# Altium schematic sheet FPGAboard.SchDoc(FPGAboard) (continued)

## Library data of the parts
C6-C8 C10-C19 C26 C27 C30-C43 C46-C48 = C0805C104K5RACTU: Manufacturer Part Number: C0805C104K5RAC-TU; Manufacturer: Kemet; Voltage, Rating: 50 VDC; Tolerance: -10, +10 %; Material, Element: Ceramic; Dielectric Characteristic: X7R; Brand/Series: C Series; Termination: SMD; Supplier Part Number 1: 399-1170-1-ND; Supplier 1: Digikey; Stock 1: 2895664; Pricing 1: 1=0.1, 10=0.053, 25=0.0388, 50=0.029, 100=0.0237, 250=0.0198, 500=0.0169, 1000=0.0133 (USD); Packaging: Cut Tape; Mounting Type: PCB; Dimensions: 0.079" x 0.049" (2 x 1.25mm) LxW; ComponentLink5URL: https://datasheet.ciiva.com/18410/70095383-18410815.pdf; ComponentLink5Description: https://datasheet.ciiva.com/18410/70095383-18410815.pdf; ComponentLink4URL: https://datasheet.ciiva.com/32431/slceramic-chip-condensers-e-32431755.pdf; ComponentLink4Description: https://datasheet.ciiva.com/32431/slceramic-chip-condensers-e-32431755.pdf; ComponentLink3URL: https://datasheet.ciiva.com/7842/1851736-7842539.pdf; ComponentLink3Description: https://datasheet.ciiva.com/7842/1851736-7842539.pdf; ComponentLink2URL: https://datasheet.ciiva.com/28682/1851736-28682232.pdf; ComponentLink2Description: https://datasheet.ciiva.com/28682/1851736-28682232.pdf; ComponentLink1URL: https://datasheet.ciiva.com/14589/70095383-14589048.pdf; ComponentLink1Description: https://datasheet.ciiva.com/14589/70095383-14589048.pdf; Component Kind: Standard; Case Size: 0805 (2012 mm); Library Name: FPGAboard.SchLib
C9 = C0805C104K5RACTU: Manufacturer Part Number: C0805C104K5RAC-TU; Manufacturer: Kemet; Voltage, Rating: 50 VDC; Tolerance: -10, +10 %; Material, Element: Ceramic; Dielectric Characteristic: X7R; Capacitance: 0.1 μF; Brand/Series: C Series; Termination: SMD; Supplier Part Number 1: 399-1170-1-ND; Supplier 1: Digikey; Stock 1: 2895664; Pricing 1: 1=0.1, 10=0.053, 25=0.0388, 50=0.029, 100=0.0237, 250=0.0198, 500=0.0169, 1000=0.0133 (USD); Packaging: Cut Tape; Mounting Type: PCB; Dimensions: 0.079" x 0.049" (2 x 1.25mm) LxW; ComponentLink5URL: https://datasheet.ciiva.com/18410/70095383-18410815.pdf; ComponentLink5Description: https://datasheet.ciiva.com/18410/70095383-18410815.pdf; ComponentLink4URL: https://datasheet.ciiva.com/32431/slceramic-chip-condensers-e-32431755.pdf; ComponentLink4Description: https://datasheet.ciiva.com/32431/slceramic-chip-condensers-e-32431755.pdf; ComponentLink3URL: https://datasheet.ciiva.com/7842/1851736-7842539.pdf; ComponentLink3Description: https://datasheet.ciiva.com/7842/1851736-7842539.pdf; ComponentLink2URL: https://datasheet.ciiva.com/28682/1851736-28682232.pdf; ComponentLink2Description: https://datasheet.ciiva.com/28682/1851736-28682232.pdf; ComponentLink1URL: https://datasheet.ciiva.com/14589/70095383-14589048.pdf; ComponentLink1Description: https://datasheet.ciiva.com/14589/70095383-14589048.pdf; Component Kind: Standard; Case Size: 0805 (2012 mm); Library Name: FPGAboard.SchLib
C20 C23 = C0805C474K5RACTU: Part # Aliases: C0805C474K5RAC7800; Manufacturer: KEMET; Voltage Rating DC: 50 V; Type: SMD MLCC X7R 6.3 - 250 VDC Commerical Grade; Tolerance: 10 %; Temperature Coefficient / Code: +/- 15 %; Dielectric: X7R; Class: Class 1; Case Width: 1.2 mm; Case Length: 2 mm; Case Height: 1 mm; Capacitance - pF: 470000 pF; Capacitance: 0.47 uF; Voltage Rating: 50 V; Termination Style: SMD/SMT; Supplier Part Number 1: 399-8100-1-ND; Supplier 1: Digikey; Stock 1: 94831; Series: C0805C; RoHS: Details; Product Category: Multilayer Ceramic Capacitors MLCC - SMD/SMT; Product: General Type MLCCs; Pricing 1: 1=0.28, 10=0.193, 100=0.1092, 500=0.0771, 1000=0.0675 (USD); Packaging: Reel; Package / Case: 0805 (2012 metric); Operating Temperature Range: - 55 C to + 125 C; Minimum Operating Temperature: - 55 C; Maximum Operating Temperature: + 125 C; Manufacturer Part Number: C0805C474K5RACTU; Factory Pack Quantity: 2500; Dimensions: 1.2 mm W x 2 mm L x 1 mm H; ComponentLink7URL: https://datasheet.ciiva.com/14589/70095383-14589439.pdf; ComponentLink7Description: https://datasheet.ciiva.com/14589/70095383-14589439.pdf; ComponentLink6URL: https://datasheet.ciiva.com/14604/70095383-14604428.pdf; ComponentLink6Description: https://datasheet.ciiva.com/14604/70095383-14604428.pdf; ComponentLink5URL: https://datasheet.ciiva.com/5411/kem-c1002-x7r-smd-5411451.pdf; ComponentLink5Description: https://datasheet.ciiva.com/5411/kem-c1002-x7r-smd-5411451.pdf; ComponentLink4URL: https://datasheet.ciiva.com/28614/1918693-28614762.pdf; ComponentLink4Description: https://datasheet.ciiva.com/28614/1918693-28614762.pdf; ComponentLink3URL: https://datasheet.ciiva.com/6126/kem-c1002-x7r-smd-6126343.pdf; ComponentLink3Description: https://datasheet.ciiva.com/6126/kem-c1002-x7r-smd-6126343.pdf; ComponentLink2URL: https://datasheet.ciiva.com/28688/1851736-28688678.pdf; ComponentLink2Description: https://datasheet.ciiva.com/28688/1851736-28688678.pdf; ComponentLink1URL: https://datasheet.ciiva.com/7842/1851736-7842613.pdf; ComponentLink1Description: https://datasheet.ciiva.com/7842/1851736-7842613.pdf; Component Kind: Standard; Case Code - mm: 2012; Case Code - in: 0805; Brand: Kemet; Library Name: FPGAboard.SchLib
C21 C22 = C0805C120J5GACTU: Part # Aliases: C0805C120J5GAC7800; Manufacturer: KEMET; Voltage Rating DC: 50 V; Type: SMD MLCC C0G 10 - 250 VDC Commercial Grade; Tolerance: 5 %; Temperature Coefficient / Code: +/- 30 PPM / C; Dissipation Factor DF: 0.1; Dielectric: C0G (NP0); Class: Class 1; Case Width: 1.2 mm; Case Length: 2 mm; Case Height: 0.78 mm; Voltage Rating: 50 V; Termination Style: SMD/SMT; Supplier Part Number 1: 399-1110-1-ND; Supplier 1: Digikey; Stock 1: 56795; Series: C0805C; RoHS: Details; Product Category: Multilayer Ceramic Capacitors MLCC - SMD/SMT; Product: General Type MLCCs; Pricing 1: 1=0.12, 10=0.085, 100=0.0402, 500=0.0282, 1000=0.0233 (USD); Packaging: Reel; Package / Case: 0805 (2012 metric); Operating Temperature Range: - 55 C to + 125 C; Minimum Operating Temperature: - 55 C; Maximum Operating Temperature: + 125 C; Manufacturer Part Number: C0805C120J5GACTU; Lead Spacing: 0.75 mm; Factory Pack Quantity: 4000; Dimensions: 1.2 mm W x 2 mm L x 0.78 mm H; ComponentLink9URL: https://datasheet.ciiva.com/28696/1789732-28696013.pdf; ComponentLink9Description: https://datasheet.ciiva.com/28696/1789732-28696013.pdf; ComponentLink8URL: https://datasheet.ciiva.com/14586/70095383-14586716.pdf; ComponentLink8Description: https://datasheet.ciiva.com/14586/70095383-14586716.pdf; ComponentLink7URL: https://datasheet.ciiva.com/7842/1732692-7842204.pdf; ComponentLink7Description: https://datasheet.ciiva.com/7842/1732692-7842204.pdf; ComponentLink6URL: https://datasheet.ciiva.com/7842/1732692-7842203.pdf; ComponentLink6Description: https://datasheet.ciiva.com/7842/1732692-7842203.pdf; ComponentLink5URL: https://datasheet.ciiva.com/6136/1732692-6136713.pdf; ComponentLink5Description: https://datasheet.ciiva.com/6136/1732692-6136713.pdf; ComponentLink4URL: https://datasheet.ciiva.com/5418/kem-c1003-c0g-smd-356956-5418089.pdf; ComponentLink4Description: https://datasheet.ciiva.com/5418/kem-c1003-c0g-smd-356956-5418089.pdf; ComponentLink3URL: https://datasheet.ciiva.com/18193/getdatasheetpartid-179336-18193526.pdf; ComponentLink3Description: https://datasheet.ciiva.com/18193/getdatasheetpartid-179336-18193526.pdf; ComponentLink2URL: https://datasheet.ciiva.com/11463/kem-c1003-c0g-smd-11463493.pdf; ComponentLink2Description: https://datasheet.ciiva.com/11463/kem-c1003-c0g-smd-11463493.pdf; ComponentLink1URL: https://datasheet.ciiva.com/144/docfinderpartnumber-c0805c120j5gactu-144980.pdf; ComponentLink1Description: https://datasheet.ciiva.com/144/docfinderpartnumber-c0805c120j5gactu-144980.pdf; Component Kind: Standard; Case Code - mm: 2012; Case Code - in: 0805; Brand: Kemet; Library Name: FPGAboard.SchLib
C24 C25 C45 = CMP-1036-04876-1: GRM21BR60J476ME15L; Value: 4.7uF; Tolerance: Â±10%; Case-Metric: 2012; Case-EIA: 0805; Technology: SMT; Rated Voltage: 6.3 V; Max Thickness: 1.45 mm; Library Name: Altium Content Vault; Component Kind: Standard
C28 C29 = CMP-1038-02286-1: C1210C226M3PACTU; Tolerance: -20% to +80%; Case-Metric: 3225; Case-EIA: 1210; Technology: SMT; Rated Voltage: 25 V; Max Thickness: 2.2 mm; Library Name: Altium Content Vault; Component Kind: Standard
C44 C49 = CMP-1035-01192-1: GRM188C81E475KE11D; Value: 75pF; Tolerance: Â±10%; Case-Metric: 1608; Case-EIA: 0603; Technology: SMT; Rated Voltage: 25 V; Max Thickness: 1 mm; Library Name: Altium Content Vault; Component Kind: Standard
CLKOUT Done FLAGA Prog TP1 = TP: Test point; Component Kind: Standard; Library Name: FPGAboard.SchLib
CON1 = T1M-06-F-SH-L-K: 6 Positions Header Connector Surface Mount, Right Angle; Manufacturer: Samtec; Supplier Part Number 1: SAM11991-ND; Supplier 1: Digi-Key; Stock 1: 0; Manufacturer Part Number: T1M-06-F-SH-L-K; Component Kind: Standard; Library Name: FPGAboard.SchLib
CON2 = SAMTEC_LSEM_V_50: SAMTEC connector; Component Kind: Standard; Library Name: FPGAboard.SchLib
CON3 = T1M-10-F-SH-L-K: 10 Positions Header Connector Surface Mount, Right Angle; Component Kind: Standard; Library Name: FPGAboard.SchLib
CON4 = Header 13X2: Header, 13-Pin, Dual row; Signal Integrity: Connector; Library Name: Miscellaneous Connectors.IntLib; LatestRevisionNote: Re-released for DXP Platform.; LatestRevisionDate: 17-Jul-2002; Component Kind: Standard; Publisher: Altium Limited
CON5 = Header 10: Header, 10-Pin; Signal Integrity: Connector; Library Name: Miscellaneous Connectors.IntLib; LatestRevisionNote: Re-released for DXP Platform.; LatestRevisionDate: 17-Jul-2002; Component Kind: Standard; Publisher: Altium Limited
MCX = 0734151061: CONN MCX RCPT STR 50OHM EDGE MNT; Manufacturer: Molex; Voltage Rating: 335V; Shield Termination: Solder; Other Names: 0734-15-1061  073415-1061  0734151061-ND  73415-1061  734151061  WM9351-2-ND  WM9351TR; Online Catalog: MCX, 73366 and 73415 Series; Frequency - Max: 6GHz; Featured Product: MCX RF Connectors; Dielectric Material: Polytetrafluoroethylene (PTFE); Connector Type: Receptacle, Female Socket; Center Contact Plating: Gold; Center Contact Material: Beryllium Copper; Body Material: Brass; Supplier Part Number 1: WM9351CT-ND; Supplier 1: Digi-Key; Stock 1: 18986; Standard Package: 1,000; Series: 73415; RoHS Information: 0734151061 Cert of Compliance; Pricing 1: 1=5.45, 10=5.23, 25=4.7944, 50=4.5764, 100=4.3584, 250=3.8136, 500=3.7047 (USD); Packaging: Tape & Reel (TR); Operating Temperature: -55°C ~ 155°C; Mounting Type: Board Edge, Cutout; Manufacturer Part Number: 0734151061; Ingress Protection: -; Impedance: 50 Ohm; Housing Color: Gold; Features: -; Fastening Type: Snap-On; Family: Coaxial Connectors (RF); Contact Termination: Solder; Connector Style: MCX; ComponentLink2URL: https://datasheet.ciiva.com/7002/0734151061-rf-coax-connectors-7002509.pdf; ComponentLink2Description: https://datasheet.ciiva.com/7002/0734151061-rf-coax-connectors-7002509.pdf; ComponentLink1URL: https://datasheet.ciiva.com/7002/0734151061-rf-coax-connectors-7002503.pdf; ComponentLink1Description: https://datasheet.ciiva.com/7002/0734151061-rf-coax-connectors-7002503.pdf; Component Kind: Standard; Category: Connectors, Interconnects; Cable Group: -; Body Finish: Gold; Library Name: FPGAboard.SchLib
OSC1 = ASVMB-100.000MHZ-XY-T: Manufacturer: Abracon; Standard Frequency: 100 MHz; Rad Hard: No; Load Capacitance: 15 pF; Frequency Stability: ±10 ppm; Type: Silicon Oscillator; Supplier Part Number 1: 535-11246-1-ND; Supplier 1: Digikey; Stock 1: 1752; Pricing 1: 1=2.51, 10=2.449, 50=2.332, 100=2.0405, 500=1.9822 (USD); Packaging: Tape & Reel; Package: 4QFN EP; Manufacturer Part Number: ASVMB-100.000MHZ-XY-T; ComponentLink4URL: https://datasheet.ciiva.com/866/asvmb-866793.pdf; ComponentLink4Description: https://datasheet.ciiva.com/866/asvmb-866793.pdf; ComponentLink3URL: https://datasheet.ciiva.com/866/asvmb-866792.pdf; ComponentLink3Description: https://datasheet.ciiva.com/866/asvmb-866792.pdf; ComponentLink2URL: https://datasheet.ciiva.com/866/asvmb-866791.pdf; ComponentLink2Description: https://datasheet.ciiva.com/866/asvmb-866791.pdf; ComponentLink1URL: https://datasheet.ciiva.com/13167/asvmb-35165-13167142.pdf; ComponentLink1Description: https://datasheet.ciiva.com/13167/asvmb-35165-13167142.pdf; Component Kind: Standard; Category: SMD Crystal Oscillator; Library Name: FPGAboard.SchLib
R6 R9 R10 = CRCW080510K0FKEA_: Manufacturer: Vishay; Voltage Rating: 150 V; Unit Weight: 0.000194 oz; Tolerance: 1 %; Temperature Coefficient: 100 PPM / K; Power Rating: 125 mW (1/8 W); Case Width: 1.25 mm; Case Length: 2 mm; Case Height: 0.45 mm; Brand: Vishay / Dale; Supplier Part Number 1: 541-10.0KCCT-ND; Supplier 1: Digikey; Stock 1: 0; Series: CRCW; RoHS: Details; Product Category: Thick Film Resistors - SMD; Product: Thick Film Resistors SMD; Pricing 1: 1=0.1, 10=0.042, 25=0.0304, 50=0.0232, 100=0.017, 250=0.013, 500=0.0104, 1000=0.0077 (USD); Packaging: Reel; Package / Case: 0805 (2012 metric); Operating Temperature Range: - 55 C to + 155 C; Manufacturer Part Number: CRCW080510K0FKEA; Factory Pack Quantity: 5000; ComponentLink10URL: https://datasheet.ciiva.com/16199/dcrcwe3-16199086.pdf; ComponentLink10Description: https://datasheet.ciiva.com/16199/dcrcwe3-16199086.pdf; ComponentLink9URL: https://datasheet.ciiva.com/8337/dcrcwe3-8337774.pdf; ComponentLink9Description: https://datasheet.ciiva.com/8337/dcrcwe3-8337774.pdf; ComponentLink8URL: https://datasheet.ciiva.com/46394/1720486-46394381.pdf; ComponentLink8Description: https://datasheet.ciiva.com/46394/1720486-46394381.pdf; ComponentLink7URL: https://datasheet.ciiva.com/16755/70201119-16755389.pdf; ComponentLink7Description: https://datasheet.ciiva.com/16755/70201119-16755389.pdf; ComponentLink6URL: https://datasheet.ciiva.com/8337/dcrcwe3-8337773.pdf; ComponentLink6Description: https://datasheet.ciiva.com/8337/dcrcwe3-8337773.pdf; ComponentLink5URL: https://datasheet.ciiva.com/16883/dcrcwe3-16883708.pdf; ComponentLink5Description: https://datasheet.ciiva.com/16883/dcrcwe3-16883708.pdf; ComponentLink4URL: https://datasheet.ciiva.com/16514/dcrcwe3-16514907.pdf; ComponentLink4Description: https://datasheet.ciiva.com/16514/dcrcwe3-16514907.pdf; ComponentLink3URL: https://datasheet.ciiva.com/16719/70201119-16719082.pdf; ComponentLink3Description: https://datasheet.ciiva.com/16719/70201119-16719082.pdf; ComponentLink2URL: https://datasheet.ciiva.com/11808/0900766b80d98b26-11808216.pdf; ComponentLink2Description: https://datasheet.ciiva.com/11808/0900766b80d98b26-11808216.pdf; ComponentLink1URL: https://datasheet.ciiva.com/5547/dcrcwe3-109170-5547559.pdf; ComponentLink1Description: https://datasheet.ciiva.com/5547/dcrcwe3-109170-5547559.pdf; Component Kind: Standard; Case Code - mm: 2012; Case Code - in: 0805; Library Name: FPGAboard.SchLib
R7 R8 = CRCW08052K20FKEA: Manufacturer: Vishay; Voltage Rating: 150 V; Unit Weight: 0.000194 oz; Tolerance: 1 %; Temperature Coefficient: 100 PPM / K; Power Rating: 125 mW (1/8 W); Brand: Vishay / Dale; Width: 1.25 mm; Termination Style: SMD/SMT; Supplier Part Number 1: 541-2.20KCCT-ND; Supplier 1: Digikey; Stock 1: 0; Series: CRCW; RoHS: Details; Product Category: Thick Film Resistors - SMD; Product: Thick Film Resistors SMD; Pricing 1: 1=0.1, 10=0.046, 100=0.0187, 1000=0.0084, 2500=0.0073 (USD); Packaging: Reel; Package / Case: 0805 (2012 metric); Operating Temperature Range: - 55 C to + 155 C; Manufacturer Part Number: CRCW08052K20FKEA; Length: 2 mm; Height: 0.45 mm; Factory Pack Quantity: 5000; ComponentLink12URL: https://datasheet.ciiva.com/3258/dcrcwe3-3258431.pdf; ComponentLink12Description: https://datasheet.ciiva.com/3258/dcrcwe3-3258431.pdf; ComponentLink11URL: https://datasheet.ciiva.com/2525/dcrcwe3-2525871.pdf; ComponentLink11Description: https://datasheet.ciiva.com/2525/dcrcwe3-2525871.pdf; ComponentLink10URL: https://datasheet.ciiva.com/3258/dcrcwe3-3258430.pdf; ComponentLink10Description: https://datasheet.ciiva.com/3258/dcrcwe3-3258430.pdf; ComponentLink9URL: https://datasheet.ciiva.com/2525/dcrcwe3-2525870.pdf; ComponentLink9Description: https://datasheet.ciiva.com/2525/dcrcwe3-2525870.pdf; ComponentLink8URL: https://datasheet.ciiva.com/2525/dcrcwe3-2525869.pdf; ComponentLink8Description: https://datasheet.ciiva.com/2525/dcrcwe3-2525869.pdf; ComponentLink7URL: https://datasheet.ciiva.com/16770/70201119-16770763.pdf; ComponentLink7Description: https://datasheet.ciiva.com/16770/70201119-16770763.pdf; ComponentLink6URL: https://datasheet.ciiva.com/7777/1720486-7777611.pdf; ComponentLink6Description: https://datasheet.ciiva.com/7777/1720486-7777611.pdf; ComponentLink5URL: https://datasheet.ciiva.com/16201/dcrcwe3-16201450.pdf; ComponentLink5Description: https://datasheet.ciiva.com/16201/dcrcwe3-16201450.pdf; ComponentLink4URL: https://datasheet.ciiva.com/7777/1697337-7777385.pdf; ComponentLink4Description: https://datasheet.ciiva.com/7777/1697337-7777385.pdf; ComponentLink3URL: https://datasheet.ciiva.com/17201/dcrcwe3-17201814.pdf; ComponentLink3Description: https://datasheet.ciiva.com/17201/dcrcwe3-17201814.pdf; ComponentLink2URL: https://datasheet.ciiva.com/5546/dcrcwe3-109170-5546726.pdf; ComponentLink2Description: https://datasheet.ciiva.com/5546/dcrcwe3-109170-5546726.pdf; ComponentLink1URL: https://datasheet.ciiva.com/11808/0900766b80d98b26-11808384.pdf; ComponentLink1Description: https://datasheet.ciiva.com/11808/0900766b80d98b26-11808384.pdf; Component Kind: Standard; Case Code - mm: 2012; Case Code - in: 0805; Library Name: FPGAboard.SchLib
R11 = CRCW08050000Z0EA: Manufacturer: Vishay; Tolerance: Jumper; Power (Watts): 0.125W, 1/8W; Other Names: 541-0.0ACT; Online Catalog: CRCW - Automotive Series; Kits: 541-1420-ND  - RESISTOR KIT 0-1M 1/8W 6100PCS; EDA / CAD Models: Download from Accelerated Designs; Temperature Coefficient: -; Supplier Part Number 1: 541-0.0ACT-ND; Supplier Device Package: 0805 (2012 Metric); Supplier 1: Digikey; Stock 1: 1015935; Standard Package: 1; Size / Dimension: 0.079" L x 0.049" W (2.00mm x 1.25mm); Series: CRCW; Pricing 1: 1=0.1, 10=0.026, 25=0.0184, 50=0.014, 100=0.0104, 250=0.0079, 500=0.0063, 1000=0.0047 (USD); Packaging: Cut Tape (CT); Package / Case: 0805 (2012 Metric); Operating Temperature: -55°C ~ 155°C; Number of Terminations: 2; Manufacturer Part Number: CRCW08050000Z0EA; Height: 0.020" (0.50mm); Features: Automotive AEC-Q200; Family: Chip Resistor - Surface Mount; Composition: Thick Film; ComponentLink11URL: https://datasheet.ciiva.com/7841/1720486-7841839.pdf; ComponentLink11Description: https://datasheet.ciiva.com/7841/1720486-7841839.pdf; ComponentLink10URL: https://datasheet.ciiva.com/2463/dcrcwe3-2463039.pdf; ComponentLink10Description: https://datasheet.ciiva.com/2463/dcrcwe3-2463039.pdf; ComponentLink9URL: https://datasheet.ciiva.com/2463/dcrcwe3-2463038.pdf; ComponentLink9Description: https://datasheet.ciiva.com/2463/dcrcwe3-2463038.pdf; ComponentLink8URL: https://datasheet.ciiva.com/7841/1697337-7841629.pdf; ComponentLink8Description: https://datasheet.ciiva.com/7841/1697337-7841629.pdf; ComponentLink7URL: https://datasheet.ciiva.com/2463/dcrcwe3-2463037.pdf; ComponentLink7Description: https://datasheet.ciiva.com/2463/dcrcwe3-2463037.pdf; ComponentLink6URL: https://datasheet.ciiva.com/16719/70201119-16719706.pdf; ComponentLink6Description: https://datasheet.ciiva.com/16719/70201119-16719706.pdf; ComponentLink5URL: https://datasheet.ciiva.com/16389/dcrcwe3-16389338.pdf; ComponentLink5Description: https://datasheet.ciiva.com/16389/dcrcwe3-16389338.pdf; ComponentLink4URL: https://datasheet.ciiva.com/11811/0900766b80d98b26-11811304.pdf; ComponentLink4Description: https://datasheet.ciiva.com/11811/0900766b80d98b26-11811304.pdf; ComponentLink3URL: https://datasheet.ciiva.com/5543/dcrcwe3-109170-5543096.pdf; ComponentLink3Description: https://datasheet.ciiva.com/5543/dcrcwe3-109170-5543096.pdf; ComponentLink2URL: https://datasheet.ciiva.com/26314/fld-crcw-e3-data-en-26314630.pdf; ComponentLink2Description: https://datasheet.ciiva.com/26314/fld-crcw-e3-data-en-26314630.pdf; ComponentLink1URL: https://datasheet.ciiva.com/16883/dcrcwe3-16883622.pdf; ComponentLink1Description: https://datasheet.ciiva.com/16883/dcrcwe3-16883622.pdf; Component Kind: Standard; Category: Resistors; Library Name: FPGAboard.SchLib
R12-R14 R16 = RES 0402: 402 Resistor; Library Name: UNP2.SchLib; Component Kind: Standard
R15 = RES 0603: 603 Resistor; Library Name: UNP2.SchLib; Component Kind: Standard
SW2 = PTS645SM43SMTR92 LFS: SWITCH TACTILE SPST-NO 0.05A 12V; Manufacturer: ITT /  C&K Components; Switch Configuration: N.O.; Pole Throw Configuration: SPST; Operating Force: 1.57 N; Minimum Insulation Resistance: 100000 MOhm; Mechanical Life: 100000 Cycles; Maximum Contact Resistance: 100 mOhm; Illumination Type: N/R; Ground Terminal: No; Dielectric Strength: 250 VAC; Contact Rating @ Voltage: 0.05@12VDC A; Body Orientation: Straight; Actuator Style: Round Button; Actuator Length: 0.85 mm; Type: N/A; Supplier Standard Pack: 1,000; Supplier Part Number 1: CKN9112CT-ND; Supplier 1: C&K Components; Stock 1: 468020; Seal Location: N/A; Seal Description: N/A; RoHS: Yes; Product Weight: N/A; Pricing 1: 1=0.15, 10=0.147, 25=0.1416, 50=0.1366, 100=0.129, 250=0.1214, 500=0.1164 (USD); Part No: PTS645SM43SMTR92LFS; Packaging: Tape and Reel; Operating Temperature: -20 to 60 C; Mounting: Surface Mount,Gull Wing; Maximum DC Voltage Rating: 12 V; Maximum AC Voltage Rating: N/A; Manufacturer Part Number: PTS645SM43SMTR92LFS; Housing Material: N/A; Family: PTS645; Electrical Life: N/A; Dimension: 6 x 9 x 4.3 mm; Contact Plating: Silver; Contact Material: N/A; ComponentLink5URL: https://datasheet.ciiva.com/29931/getdatasheetpartid-764615-29931183.pdf; ComponentLink5Description: https://datasheet.ciiva.com/29931/getdatasheetpartid-764615-29931183.pdf; ComponentLink4URL: https://datasheet.ciiva.com/14920/pts645-14920735.pdf; ComponentLink4Description: https://datasheet.ciiva.com/14920/pts645-14920735.pdf; ComponentLink3URL: https://datasheet.ciiva.com/14920/pts645-14920734.pdf; ComponentLink3Description: https://datasheet.ciiva.com/14920/pts645-14920734.pdf; ComponentLink2URL: https://datasheet.ciiva.com/14920/pts645-14920733.pdf; ComponentLink2Description: https://datasheet.ciiva.com/14920/pts645-14920733.pdf; ComponentLink1URL: https://datasheet.ciiva.com/29903/getdatasheetpartid-786341-29903846.pdf; ComponentLink1Description: https://datasheet.ciiva.com/29903/getdatasheetpartid-786341-29903846.pdf; Component Kind: Standard; Base Material: N/A; Actuator Color: N/A; Library Name: FPGAboard.SchLib
U3 = CY7C68014A-56PVXC: IC MCU USB PERIPH HI SPD 56SSOP; Manufacturer: Cypress; Supply Voltage - Min: 3 V; Supply Voltage - Max: 3.6 V; Program Memory Type: ROMLess; Processor Series: CY7C68xx; Operating Supply Voltage: 3 V to 3.6 V; On-Chip ADC: No; Number of Timers: 3; Number of Programmable I/Os: 24; Maximum Clock Frequency: 48 MHz; Interface Type: GPIF, I2C, USART; Data RAM Size: 16 KB; Data Bus Width: 8 bit/16 bit; Core: 8051; Supplier Part Number 1: 428-1673-ND; Supplier 1: Digikey; Stock 1: 503; RoHS: Details; Product Category: 8-bit Microcontrollers - MCU; Pricing 1: 1=13.46, 10=12.24, 52=11.3219, 104=10.404, 260=9.486, 520=8.874, 1040=8.1396 (USD); Packaging: Tube; Package / Case: SSOP-56; Operating Temperature Range: 0 C to + 70 C; Mounting Style: SMD/SMT; Minimum Operating Temperature: 0 C; Maximum Operating Temperature: + 70 C; Manufacturer Part Number: CY7C68014A-56PVXC; Factory Pack Quantity: 26; ComponentLink3URL: https://datasheet.ciiva.com/26821/cy7c68014a-56pvxc-26821370.pdf; ComponentLink3Description: https://datasheet.ciiva.com/26821/cy7c68014a-56pvxc-26821370.pdf; ComponentLink2URL: https://datasheet.ciiva.com/5824/43447-5824174.pdf; ComponentLink2Description: https://datasheet.ciiva.com/5824/43447-5824174.pdf; ComponentLink1URL: https://datasheet.ciiva.com/7627/43447-7627897.pdf; ComponentLink1Description: https://datasheet.ciiva.com/7627/43447-7627897.pdf; Component Kind: Standard; Library Name: FPGAboard.SchLib
U4 = XC6SLX9-3TQG144I: IC FPGA 102 I/O 144TQFP; Manufacturer: Xilinx; Voltage - Supply: 1.14 V ~ 1.26 V; Total RAM Bits: 589824; Product Training Modules: S6 Family Overview; PCN Design/Specification: Shipping Tray TQ144 and TQG144 12/Aug/2013; Other Names: 122-1748  XC6SLX9-3TQG144I-ND  XC6SLX93TQG144I; Online Catalog: Spartan®-6 LX; Number of Logic Elements/Cells: 9152; Number of LABs/CLBs: 715; Number of I/O: 102; For Use With: 1286-1046-ND                                                          - BOARD CMOD S6 FPGA 48DIP                                                     102990017-ND                                                          - PAPILIO PRO                                                     DEV-11953-ND                                                          - DEV BOARD MOJO V3 FPGA; Supplier Part Number 1: 122-1748-ND; Supplier Device Package: 144-TQFP (20x20); Supplier 1: Digikey; Stock 1: 2437; Standard Package: 1; Series: Spartan® 6 LX; Pricing 1: 1=20.86 (USD); Package / Case: 144-LQFP; Operating Temperature: -40°C ~ 100°C (TJ); Number of Gates: -; Mounting Type: Surface Mount; Manufacturer Part Number: XC6SLX9-3TQG144I; Family: Embedded - FPGAs (Field Programmable Gate Array); ComponentLink1URL: https://datasheet.ciiva.com/1442/ds160-1442503.pdf; ComponentLink1Description: https://datasheet.ciiva.com/1442/ds160-1442503.pdf; Component Kind: Standard; Category: Integrated Circuits (ICs); Library Name: FPGAboard.SchLib
U5 U8 = LTM4622: Dual Ultrathin 2.5A or Single 5A Step-Down DC/DC μModule Regulator; Ref: LTM4622IV#PBF; Library Name: PSSL3.SchLib; Component Kind: Standard
U6 = PRTR5V0U2X,215: TVS DIODE 5.5VWM SOT143B; Manufacturer: NXP Semiconductors; Working Voltage (Max): 5.5V; Suppressor Type: Diode Arrays; Reverse Stand-off Voltage: 5.5 V; Reverse Breakdown Voltage: 6 V; Rad Hardened: No; Product Length (mm): 3 mm; Product Height (mm): 1 mm; Product Depth (mm): 1.4 mm; Polarity: Uni-Directional; Number of  Elements: 1; Leakage Current (Max): 0.1uA; ESD Protection Voltage: 8KV@Contact Disc; Configuration: Quad; Capacitance Value: 16pF; Working Voltage: 5.5V; Supplier Part Number 1: 1727-3884-1-ND; Supplier 1: Digi-Key; Stock 1: 73838; Pricing 1: 1=0.52, 10=0.447, 100=0.334, 500=0.2624, 1000=0.2028 (USD); Pin Count: 3 +Tab; Packaging: Tape and Reel; Package Type: SOT; Package / Case: SOT; Operating Temp Range: -40C to 85C; Operating Temperature Min Deg. C: -40C; Operating Temperature Max Deg. C: 85C; Operating Temperature Classification: Industrial; Mounting Style: Surface Mount; Mounting: Surface Mount; Manufacturer Part Number: PRTR5V0U2X,215; Leakage Current: 0.1uA; Direction Type: Uni-Directional; ComponentLink9URL: https://datasheet.ciiva.com/26787/prtr5v0u2x-215-26787348.pdf; ComponentLink9Description: https://datasheet.ciiva.com/26787/prtr5v0u2x-215-26787348.pdf; ComponentLink8URL: https://datasheet.ciiva.com/31402/109718-31402206.pdf; ComponentLink8Description: https://datasheet.ciiva.com/31402/109718-31402206.pdf; ComponentLink7URL: https://datasheet.ciiva.com/11193/prtr5v0u2x-11193230.pdf; ComponentLink7Description: https://datasheet.ciiva.com/11193/prtr5v0u2x-11193230.pdf; ComponentLink6URL: https://datasheet.ciiva.com/25919/prtr5v0u2x-25919147.pdf; ComponentLink6Description: https://datasheet.ciiva.com/25919/prtr5v0u2x-25919147.pdf; ComponentLink5URL: https://datasheet.ciiva.com/335/prtr5v0u2x-335467.pdf; ComponentLink5Description: https://datasheet.ciiva.com/335/prtr5v0u2x-335467.pdf; ComponentLink4URL: https://datasheet.ciiva.com/6918/109718-6918650.pdf; ComponentLink4Description: https://datasheet.ciiva.com/6918/109718-6918650.pdf; ComponentLink3URL: https://datasheet.ciiva.com/28409/109718-28409830.pdf; ComponentLink3Description: https://datasheet.ciiva.com/28409/109718-28409830.pdf; ComponentLink2URL: https://datasheet.ciiva.com/16199/prtr5v0u2x-16199605.pdf; ComponentLink2Description: https://datasheet.ciiva.com/16199/prtr5v0u2x-16199605.pdf; ComponentLink1URL: https://datasheet.ciiva.com/4479/prtr5v0u2x-102853-4479461.pdf; ComponentLink1Description: https://datasheet.ciiva.com/4479/prtr5v0u2x-102853-4479461.pdf; Component Kind: Standard; Library Name: FPGAboard.SchLib
U7 = SN74LVC1G04QDBVRQ1: IC SNGL INVERTER GATE; Manufacturer: Texas Instruments; Voltage - Supply: 1.65 V ~ 5.5 V; Other Names: 296-26588-6; Number of Inputs: 1; Max Propagation Delay @ V, Max CL: 3.7ns @ 5V, 50pF; Logic Type: Inverter, Buffer; Logic Level - Low: 0.7 V ~ 0.8 V; Logic Level - High: 1.7 V ~ 2 V; Dynamic Catalog: 74LVC; Current - Quiescent (Max): 10µA; Current - Output High, Low: 32mA, 32mA; Supplier Part Number 1: 296-26588-1-ND; Supplier Device Package: SOT-23-5; Supplier 1: Digi-Key; Stock 1: 4515; Standard Package: 1; Series: 74LVC; Pricing 1: 1=0.35, 10=0.286, 100=0.1945, 500=0.1458, 1000=0.1094 (USD); Packaging: Digi-Reel®; Package / Case: SC-74A, SOT-753; Operating Temperature: -40°C ~ 125°C; Number of Circuits: 1; Mounting Type: Surface Mount; Manufacturer Part Number: SN74LVC1G04QDBVRQ1; Features: -; Family: Logic - Gates and Inverters; ComponentLink6URL: https://datasheet.ciiva.com/15089/getdatasheetpartid-190022-15089373.pdf; ComponentLink6Description: https://datasheet.ciiva.com/15089/getdatasheetpartid-190022-15089373.pdf; ComponentLink5URL: https://datasheet.ciiva.com/26044/sn74lvc1g04-q1-26044156.pdf; ComponentLink5Description: https://datasheet.ciiva.com/26044/sn74lvc1g04-q1-26044156.pdf; ComponentLink4URL: https://datasheet.ciiva.com/4219/sces482d-4219660.pdf; ComponentLink4Description: https://datasheet.ciiva.com/4219/sces482d-4219660.pdf; ComponentLink3URL: https://datasheet.ciiva.com/1864/sn74lvc1g04-q1-1864825.pdf; ComponentLink3Description: https://datasheet.ciiva.com/1864/sn74lvc1g04-q1-1864825.pdf; ComponentLink2URL: https://datasheet.ciiva.com/1864/sn74lvc1g04-q1-1864824.pdf; ComponentLink2Description: https://datasheet.ciiva.com/1864/sn74lvc1g04-q1-1864824.pdf; ComponentLink1URL: https://datasheet.ciiva.com/1864/sn74lvc1g04-q1-1864823.pdf; ComponentLink1Description: https://datasheet.ciiva.com/1864/sn74lvc1g04-q1-1864823.pdf; Component Kind: Standard; Category: Integrated Circuits (ICs); Library Name: FPGAboard.SchLib
Y1 = AT-24.000MAGE-T: 24MHz ±30ppm Crystal 12pF 50 Ohm -40°C ~ 85°C AEC-Q200 Surface Mount HC49/US; Manufacturer: TXC; Other Names: 887-1613-6; Operating Mode: Fundamental; Online Catalog: AT Series; Frequency Stability: ±50ppm; ESR (Equivalent Series Resistance): 50 Ohm; Type: MHz Crystal; Supplier Part Number 1: 887-1613-1-ND; Supplier 1: Digikey; Stock 1: 380; Standard Package: 1; Size / Dimension: 0.449" L x 0.189" W (11.40mm x 4.80mm); Simulation: XTAL; Series: AT; Ratings: AEC-Q200; Pricing 1: 1=0.66, 10=0.55, 50=0.495, 100=0.44, 500=0.418 (USD); Packaging: Digi-Reel®; Package / Case: HC49/US; Operating Temperature: -40°C ~ 85°C; Mounting Type: Surface Mount; Manufacturer Part Number: AT-24.000MAGE-T; Load Capacitance: 12pF; Height: 0.161" (4.10mm); Frequency Tolerance: ±30ppm; Family: Crystals; ComponentLink5URL: https://datasheet.ciiva.com/6728/at-automotive-6728860.pdf; ComponentLink5Description: https://datasheet.ciiva.com/6728/at-automotive-6728860.pdf; ComponentLink4URL: https://datasheet.ciiva.com/6728/at-automotive-6728859.pdf; ComponentLink4Description: https://datasheet.ciiva.com/6728/at-automotive-6728859.pdf; ComponentLink3URL: https://datasheet.ciiva.com/6728/at-automotive-6728858.pdf; ComponentLink3Description: https://datasheet.ciiva.com/6728/at-automotive-6728858.pdf; ComponentLink2URL: https://datasheet.ciiva.com/8047/1639142-8047989.pdf; ComponentLink2Description: https://datasheet.ciiva.com/8047/1639142-8047989.pdf; ComponentLink1URL: https://datasheet.ciiva.com/4946/at-automotive-3382-4946832.pdf; ComponentLink1Description: https://datasheet.ciiva.com/4946/at-automotive-3382-4946832.pdf; Component Kind: Standard; Category: Crystals and Oscillators; Library Name: FPGAboard.SchLib
P1-P4 = Plug: Signal Integrity: Connector; Library Name: Miscellaneous Connectors.IntLib; LatestRevisionNote: Re-released for DXP Platform.; LatestRevisionDate: 17-Jul-2002; Description: Plug; Component Kind: Standard; Publisher: Altium Limited
